# Altium schematic sheet [6]-Power Supply.SchDoc
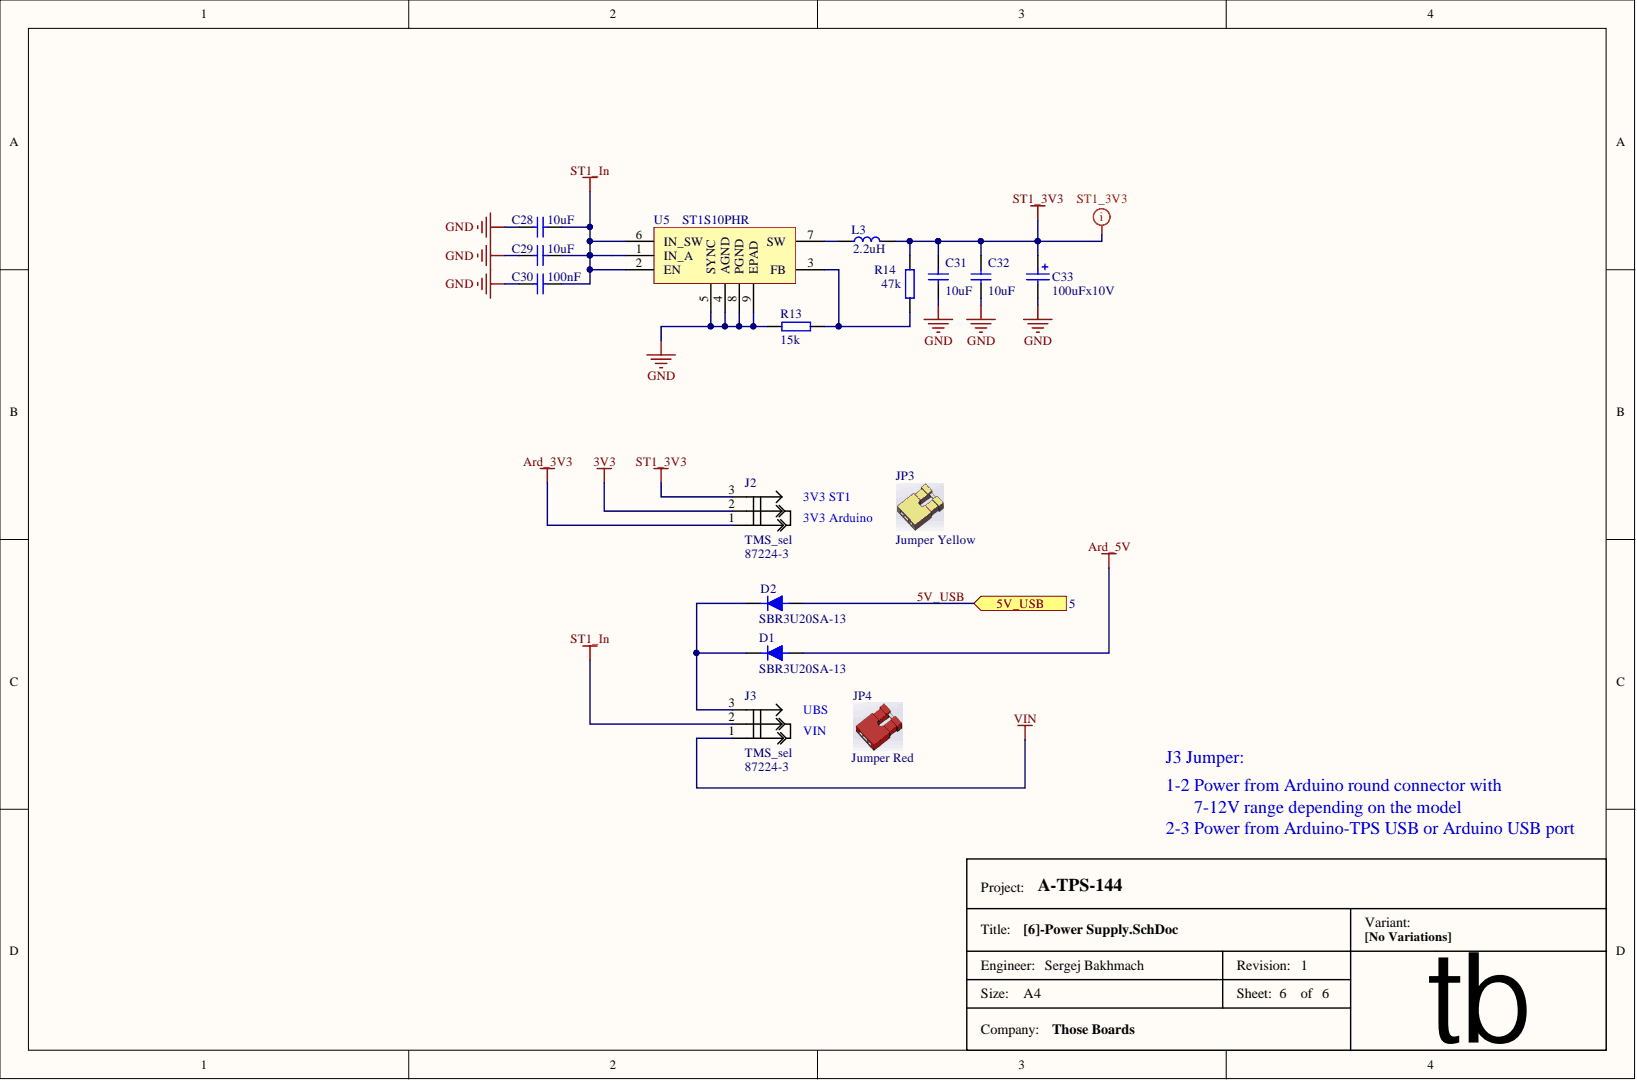
[diagram p0: [6]-Power Supply.SchDoc]

## Parts
C28  value 10uF  [C0805]  (hidden comment: CAP)
C29  value 10uF  [C0805]  (hidden comment: CAP)
C30  value 100nF  [C0603]  (hidden comment: CAP)
C31  value 10uF  [C0805]  (hidden comment: CAP)
C32  value 10uF  [C0805]  (hidden comment: CAP)
C33  value 100uFx10V  [CAP_CASE_C]  (hidden comment: uF)
D1  value SBR3U20SA-13  Manufacturer Part: SBR3U20SA-13  [SMA]  (hidden comment: Diode)
D2  value SBR3U20SA-13  Manufacturer Part: SBR3U20SA-13  [SMA]  (hidden comment: Diode)
J2  TMS_sel  value 87224-3  Manufacturer Part: 87224-3  [Jumper_3pin_yellow]
J3  TMS_sel  value 87224-3  Manufacturer Part: 87224-3  [Jumper_3pin_red]
JP3  value Jumper Yellow  Supplier Part: Jumper  (hidden comment: VCC_sel)  (mechanical)
JP4  value Jumper Red  Supplier Part: Jumper  (hidden comment: VCC_sel)  (mechanical)
L3  value 2.2uH  [LTF5022]  (hidden comment: L_LTF5022)
R13  value 15k  [0603_RESISTOR]  (hidden comment: Resistor)
R14  value 47k  [0603_RESISTOR]  (hidden comment: Resistor)
U5  ST1S10  value ST1S10PHR  Manufacturer Part: ST1S10PHR  [SO8_PWR_pad]

## Ports
5V_USB

## Nets
3V3: J2.2
ARD_3V3: J2.1
ARD_5V: D1.1
GND: C28.1 C29.1 C30.2 C31.1 C32.1 C33.2 R13.1 U5.4(AGND) U5.5(SYNC) U5.8(PGND) U5.9(EPAD)
ST1_3V3: C31.2 C32.2 C33.1 J2.3 L3.2 R14.1
ST1_IN: C28.2 C29.2 C30.1 J3.2 U5.1(IN_A) U5.2(EN) U5.6(IN_SW)
VIN: J3.1
5V_USB: D2.1 ; port 5V_USB
NetD1_2: D1.2 D2.2 J3.3
NetL3_1: L3.1 U5.7(SW)
NetR13_2: R13.2 R14.2 U5.3(FB)

## Library data of the parts
C28 C29 C31 C32 = CAP: Manufacturer: Murata; Manufacturer Part: GRM21BC81C106KE15L; Type of Component: Ceramic Capacitor; Component Kind: Standard; Manufacturer Link: http://psearch.en.murata.com/capacitor/result/smd/?status=all&pid=GRM21BC81C106KE15L; Package / Case: 0805; Supplier: mouser; Supplier Link: http://www.mouser.com/ProductDetail/Murata/GRM21BC81C106KE15L/?qs=%2fha2pyFadugq0yC%2fv5omHdWffW%252bX1z%252bEjQXmyIECJNTTTKySHodREy42G6WZAHxu; Supplier Part: 81-GRM21BC81C106KE5L; Library Name: RPI-VITA.IntLib
C30 = CAP: Manufacturer: Vishay / Vitramon; Manufacturer Part: VJ0603Y104JXJPW1BC; Type of Component: Ceramic Capacitor; Component Kind: Standard; Manufacturer Link: http://www.vishay.com/product?docid=28548; Package / Case: 0603; Supplier: mouser; Supplier Link: http://www.mouser.com/ProductDetail/Vishay/VJ0603Y104JXJPW1BC/?qs=%2fha2pyFaduidGoO0X7aK7Xgs%2fjxfhnLiK6SRw4Lz0%252bZK3PJ8DddTrQ%3d%3d; Supplier Part: 77-VJ0603Y104JXJPBC; Library Name: RPI-VITA.IntLib
C33 = Cap_pol_tant: Manufacturer: AVX; Manufacturer Part: TPSC107K010S0075; Type of Component: Tantalum Capacitor; Component Kind: Standard; Manufacturer Link: http://www.avx.com/prodinfo_productdetail.asp?I=82&K=search&C=&word=TPSC107K010S0075&form=number&Number=; Package / Case: Case C; Supplier: mouser; Supplier Link: http://www.mouser.ee/ProductDetail/AVX/TPSC107K010S0075/?qs=sGAEpiMZZMuEN2agSAc2pj5RGSp5%252bR392wzwmTGvCpg%3d; Supplier Part: 581-TPSC107K010S0075; Library Name: RPI-VITA.IntLib
D1 D2 = Diode: Schottky Diodes & Rectifiers; Manufacturer: Diodes Incorporated; Component Kind: Standard; Manufacturer Link: http://www.diodes.com/search?type=0&value=SBR3U20SA-13; Package / Case: SMA; Supplier: mouser; Supplier Link: http://www.mouser.ee/ProductDetail/Diodes-Incorporated/SBR3U20SA-13/?qs=sGAEpiMZZMtQ8nqTKtFS%2fE6cG%252brOIQfRLc3x%2fXaLBa0%3d; Supplier Part: 621-SBR3U20SA-13; Type of Component: Diode; Library Name: RPI-VITA.IntLib
J2 J3 = jumper_3pin: Manufacturer: TE Connectivity / AMP; Type of Component: Jumper; Component Kind: Standard; Manufacturer Link: http://www.te.com/usa-en/product-87224-3.html#pdp-related-products-inner; Package / Case: Header 1 x 3; Supplier: mouser; Supplier Link: http://www.mouser.ee/ProductDetail/TE-Connectivity-AMP/87224-3/?qs=sGAEpiMZZMs%252bGHln7q6pm48SVpWlpfsErguO%2fp0873w%3d; Supplier Part: 571-872243; Library Name: RPI-VITA.IntLib
JP3 = jumper yellow: Manufacturer: Great Electric; Manufacturer Part: Jumper Cap Shunts Short Circuit Cap; Package / Case: 2.54mm; Supplier: aliexpress.com; Supplier Link: http://www.aliexpress.com/item/500pcs-lot-Asssorted-Color-2-54mm-Standard-Circuit-Board-Jumper-Cap-Shunts-Short-Circuit-Cap-CGKCH119/32344305619.html?spm=2114.03010208.3.80.nPPmoy&ws_ab_test=searchweb201556_1,searchweb201644_1_505_506_503_504_502_10001_10002_10017_10010_10005_10011_10006_10003_10004_10009_10007_10008,searchweb201560_5,searchweb1451318400_-1,searchweb1451318411_6452&btsid=16d11777-edde-4755-b8b5-9adacb495b09; Type of Component: Jumper Yellow; Library Name: RPI-VITA.IntLib
JP4 = jumper red: Manufacturer: Great Electric; Manufacturer Part: Jumper Cap Shunts Short Circuit Cap; Package / Case: 2.54mm; Supplier: aliexpress.com; Supplier Link: http://www.aliexpress.com/item/500pcs-lot-Asssorted-Color-2-54mm-Standard-Circuit-Board-Jumper-Cap-Shunts-Short-Circuit-Cap-CGKCH119/32344305619.html?spm=2114.03010208.3.80.nPPmoy&ws_ab_test=searchweb201556_1,searchweb201644_1_505_506_503_504_502_10001_10002_10017_10010_10005_10011_10006_10003_10004_10009_10007_10008,searchweb201560_5,searchweb1451318400_-1,searchweb1451318411_6452&btsid=16d11777-edde-4755-b8b5-9adacb495b09; Type of Component: Jumper; Library Name: RPI-VITA.IntLib
L3 = L_LTF5022: Manufacturer: TDK; Manufacturer Part: LTF5022T-2R2N3R2-LC; Type of Component: Inductor; Component Kind: Standard; Manufacturer Link: http://product.tdk.com/inductor/ind/detailed_information.php?lang=en&part_no=LTF5022T-2R2N3R2-LC; Package / Case: 5.2 mm x 5 mm; Supplier: mouser; Supplier Link: http://www.mouser.ee/search/ProductDetail.aspx?TDK%2fLTF5022T-2R2N3R2-LC%2f&qs=%2fha2pyFadui2dkPtc8Qb7GgS2MXg2uuOAtrBGixOx605UNMElKnYgkaM4wRA6%2fNS; Supplier Part: 810-LTF5022T2R2N3R2L; Library Name: RPI-VITA.IntLib
R13 = Resistor: Manufacturer: Vishay / Dale; Manufacturer Part: CRCW060315K0FKEA; Component Kind: Standard; Manufacturer Link: http://www.vishay.com/product?docid=20035; Package / Case: 0603; Supplier: mouser; Supplier Link: http://www2.mouser.com/ProductDetail/Vishay-Dale/CRCW060315K0FKEA/?qs=sGAEpiMZZMvdGkrng054tzs1OVvhhRzmFqyUoR13SvQ%3d; Supplier Part: 71-CRCW0603-15K-E3; Type of Component: Resistor; Library Name: RPI-VITA.IntLib
R14 = Resistor: Manufacturer: Vishay / Dale; Manufacturer Part: CRCW060347K0FKEA; Component Kind: Standard; Manufacturer Link: http://www.vishay.com/product?docid=20035; Package / Case: 0603; Supplier: mouser; Supplier Link: http://www2.mouser.com/ProductDetail/Vishay-Dale/CRCW060347K0FKEA/?qs=sGAEpiMZZMvdGkrng054t4HRUGKGWf0J%252bEfP0bj7%252bjU%3d; Supplier Part: 71-CRCW0603-47K-E3; Type of Component: Resistor; Library Name: RPI-VITA.IntLib
U5 = ST1S10: Manufacturer: STMicroelectronics; Type of Component: Voltage Regulator; Component Kind: Standard; Manufacturer Link: http://www.st.com/web/en/catalog/sense_power/FM142/CL1456/SC355/PF188180?s_searchtype=keyword; Package / Case: SOIC-8; Supplier: mouser; Supplier Link: http://www.mouser.com/ProductDetail/STMicroelectronics/ST1S10PHR/?qs=sGAEpiMZZMvMXbh32ZmHAKWWuRxuuGix; Supplier Part: 511-ST1S10PHR; Library Name: RPI-VITA.IntLib
